# Altium schematic sheet CANFD.SchDoc(CANFD)
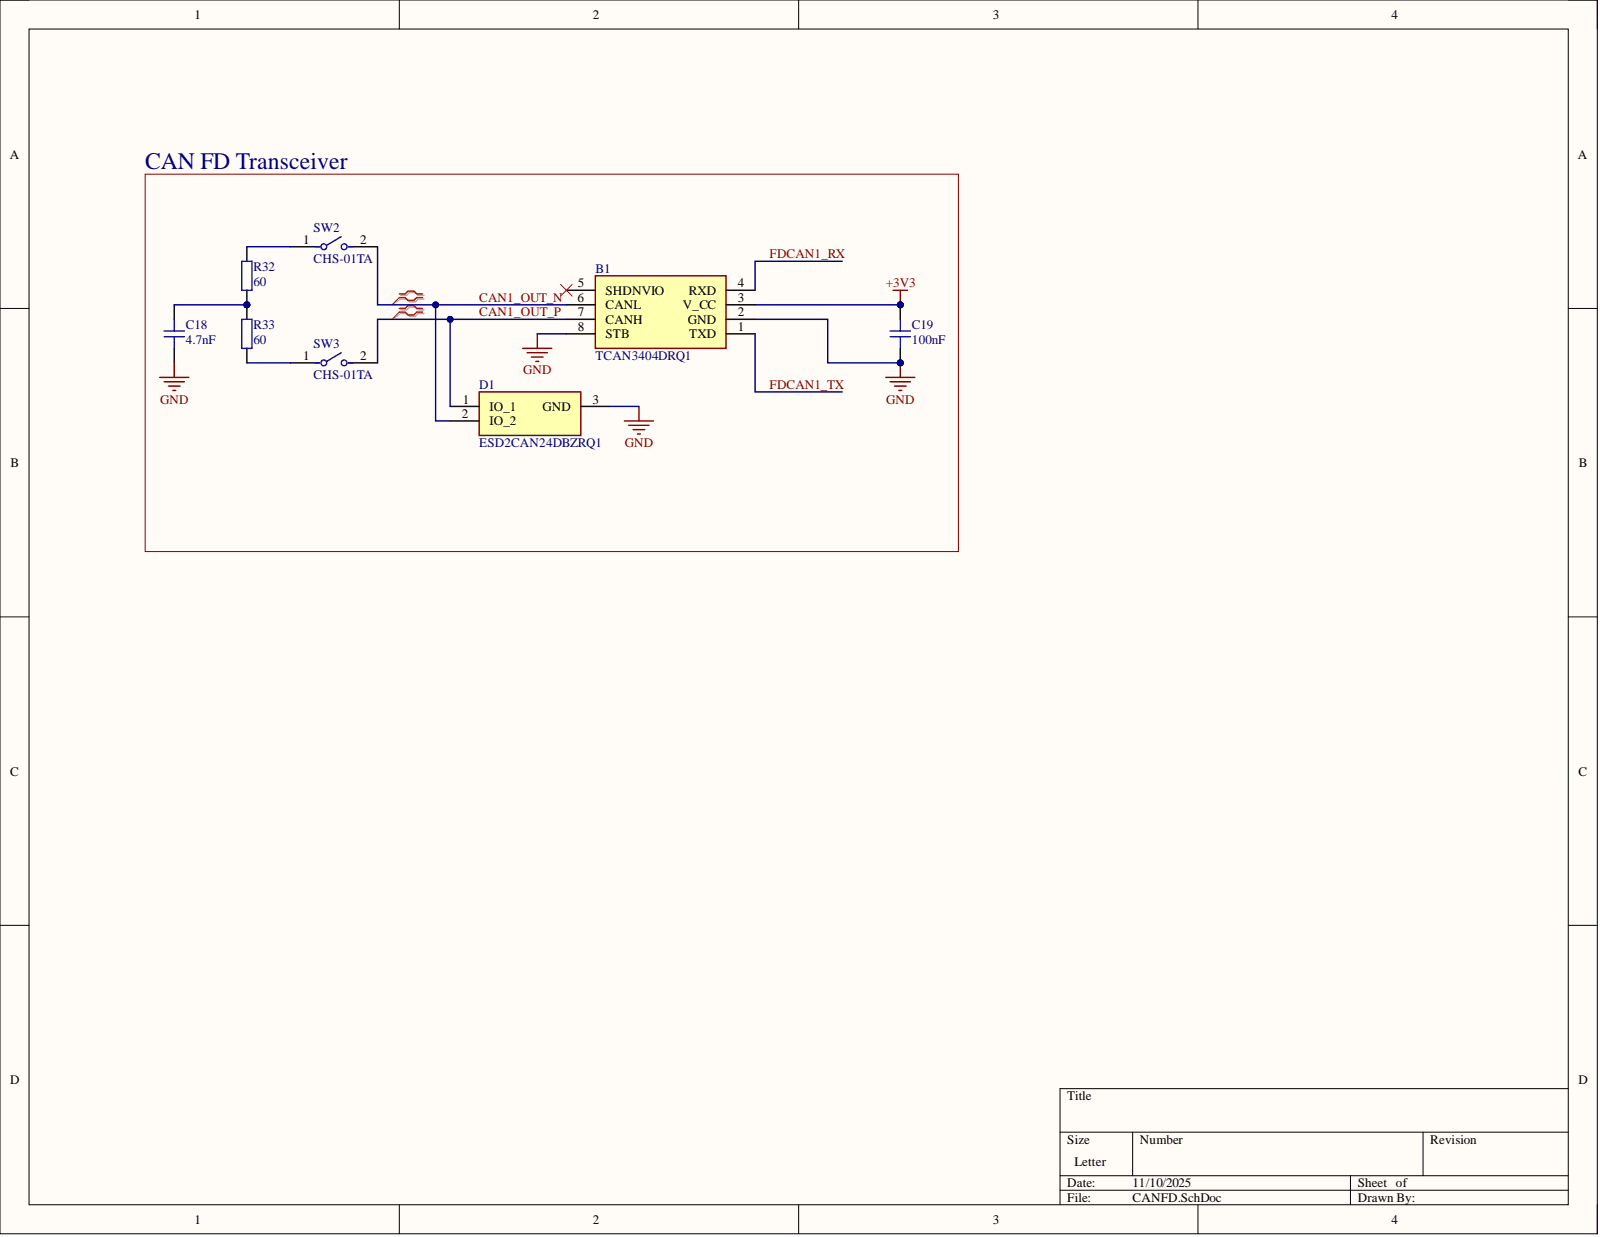
[diagram p0: CANFD.SchDoc]

## Parts
B1  TCAN3404DRQ1
C18  4.7nF
C19  100nF
D1  ESD2CAN24DBZRQ1
R32  60
R33  60
SW2  CHS-01TA
SW3  CHS-01TA

## Nets
+3V3: B1.3(V_CC) C19.2
GND: B1.2(GND) B1.8(STB) C18.1 C19.1 D1.3
CAN1_OUT_N: B1.6(CANL) D1.2 SW2.2
CAN1_OUT_P: B1.7(CANH) D1.1 SW3.2
FDCAN1_RX: B1.4(RXD)
FDCAN1_TX: B1.1(TXD)
NetC18_2: C18.2 R32.1 R33.2
NetR32_2: R32.2 SW2.1
NetR33_1: R33.1 SW3.1

Not connected: B1 5(SHDNVIO)

## Library data of the parts
B1 = CMP-288-000000-1: CAN Interface IC Automotive 3.3V CAN flexible-data-rate (FD) transceiver with shutdown and standby mode 8-SOIC -40 to 150; Manufacturer_Name: Texas Instruments; Geometry.Height: 1.75mm; Component Kind: Standard; Datasheet Link: https://www.ti.com/lit/ds/symlink/tcan3404-q1.pdf?ts=1728276225512&ref_url=https%253A%252F%252Fwww.mouser.in%252F; Design Item ID: TCAN3404DRQ1; Manufacturer_Part_Number: TCAN3404DRQ1; Mouser Part Number: 595-TCAN3404DRQ1; Mouser Price/Stock: https://www.mouser.co.uk/ProductDetail/Texas-Instruments/TCAN3404DRQ1?qs=VVKQmw408U%252B21737r85FWg%3D%3D; Source: TCAN3404DRQ1.SchLib; Library Name: Solar Gators
C18 C19 = CAP_0603: Capacitor SMD 0603; Component Kind: Standard; Library Name: Schematic-Lib-PhilsLab (1).SchLib
D1 = CMP-031-00024-3: CAN TVS Diode; Manufacturer_Name: Texas Instruments; Arrow Part Number: ESD2CAN24DBZRQ1; Arrow Price/Stock: https://www.arrow.com/en/products/esd2can24dbzrq1/texas-instruments?region=nac; Case/Package: SOT-23-3; Component Kind: Standard; Datasheet Link: https://www.ti.com/lit/ds/symlink/esd2can24-q1.pdf?ts=1669637432096&ref_url=https%253A%252F%252Fwww.ti.com%252Fproduct%252FESD2CAN24-Q1; Design Item ID: ESD2CAN24DBZRQ1; Height: 1.12mm; Manufacturer_Part_Number: ESD2CAN24DBZRQ1; Mouser Part Number: 595-ESD2CAN24DBZRQ1; Mouser Price/Stock: https://www.mouser.co.uk/ProductDetail/Texas-Instruments/ESD2CAN24DBZRQ1?qs=Y0Uzf4wQF3knJz9mU6BNuw%3D%3D; Source: SamacSys.SchLib; Library Name: Solar Gators
R32 R33 = RES_0603: Resistor SMD 0603; Component Kind: Standard; Library Name: Schematic-Lib-PhilsLab (1).SchLib
SW2 SW3 = CMP-71740-000003-1: SWITCH SLIDE DIP SPST 100MA 6V; Manufacturer: Nidec Copal Electronics; Contact Resistance: 50mΩ; Electromechanical Life: 2000Cycles; Number Of Circuits: 1; Standoff Height: 0mm; Automotive: No; Category: Switch; Component Kind: Standard; ComponentLink2Description: Datasheet; ComponentLink2URL: https://www.nidec-copal-electronics.com/e/catalog/switch/chs.pdf; Contact Current Rating: 100mA; Device Class L1: Electromechanical; Device Class L2: Switches; Device Class L3: DIP Switches; Digikey Description: SWITCH SLIDE DIP SPST 100MA 6V; Height: 2.5mm; Lead Free: Yes; Library Name: Altium Content Vault; Manufacturer Part Number: CHS-01TA; Mount: Surface Mount; Octopart: https://octopart.com/chs-01ta-nidec+copal-52304644; Package: CHS-01TA; RoHS: TRUE; Temperature: -40°C to +85°C; Temperature Range High: +85°C; Temperature Range Low: -40°C; Throw Configuration: SPST; Voltage Rating Dc: 6V
